# Altium schematic sheet IO Expander.SchDoc("IO Expander")
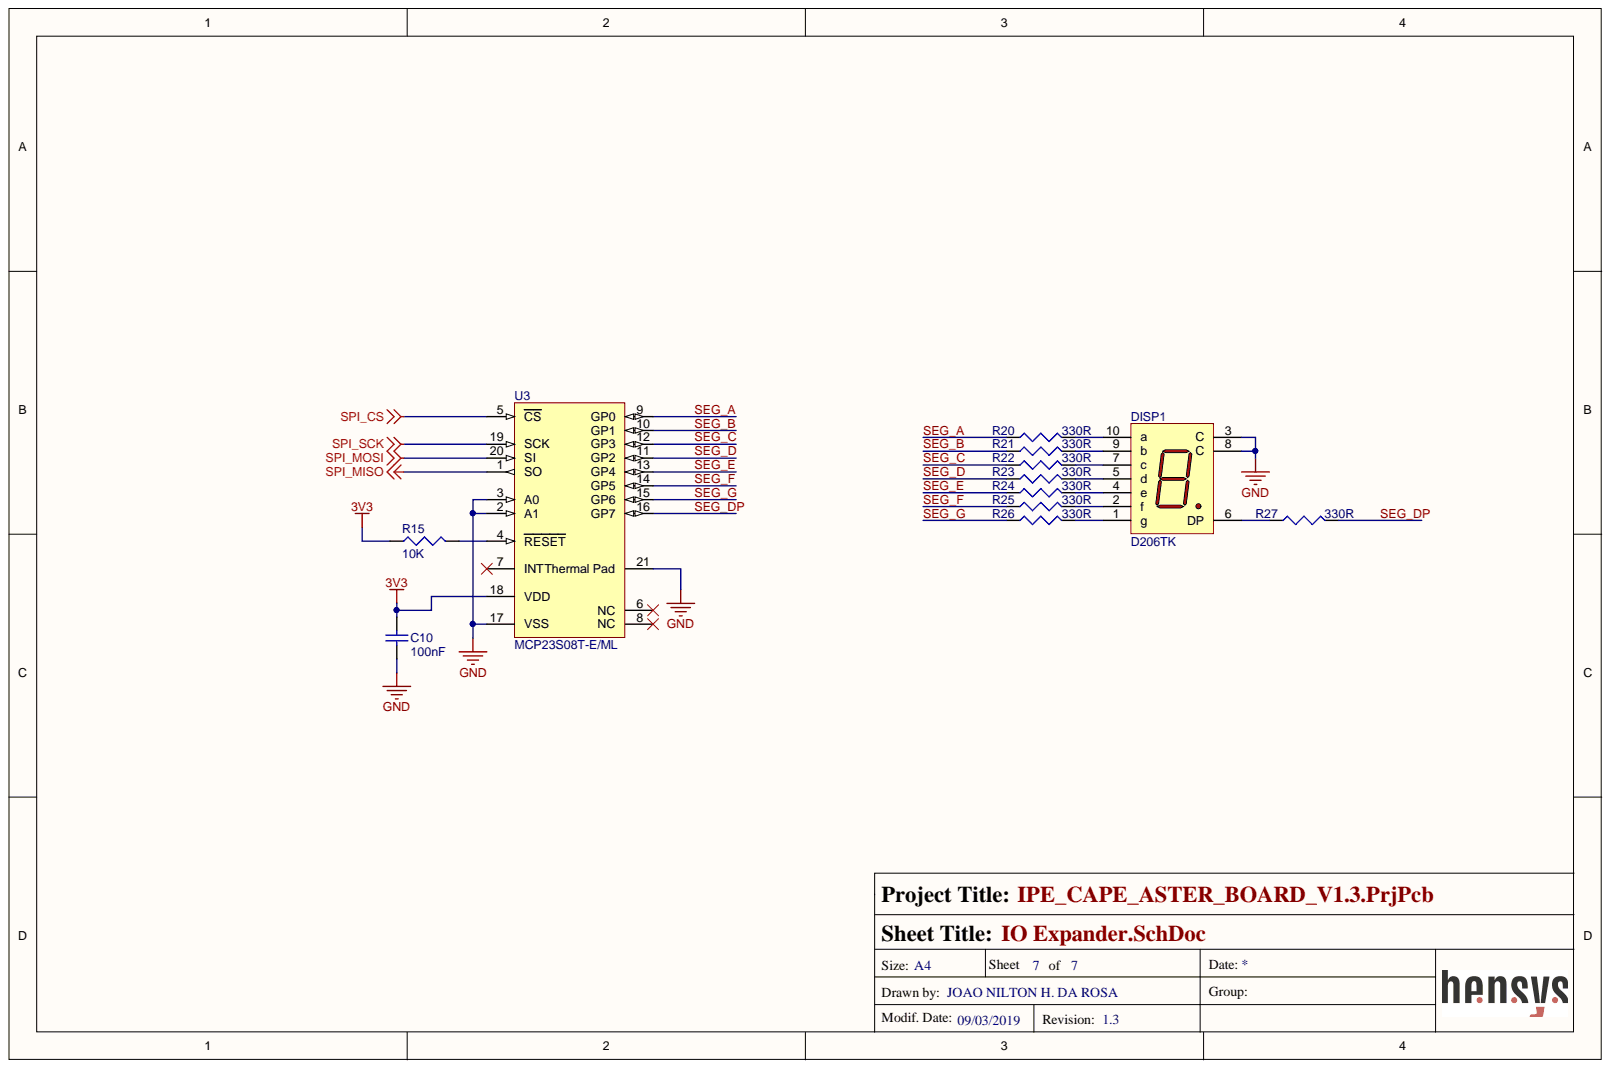
[diagram p0: IO Expander.SchDoc]

## Parts
C10  100nF  [0402 cap]
DISP1  D206TK  [D20XT_K_]
R15  10K  [0402 res]
R20  330R  [0603 res]
R21  330R  [0603 res]
R22  330R  [0603 res]
R23  330R  [0603 res]
R24  330R  [0603 res]
R25  330R  [0603 res]
R26  330R  [0603 res]
R27  330R  [0603 res]
U3  MCP23S08T-E/ML  [QFN-ML20_N]

## Nets
3V3: C10.2 R15.1 U3.18(VDD)
GND: C10.1 DISP1.3(C) DISP1.8(C) U3.2(A1) U3.3(A0) U3.17(VSS) U3.21
SPI_CS: U3.5(CS)
SPI_MISO: U3.1(SO)
SPI_MOSI: U3.20(SI)
SPI_SCK: U3.19(SCK)
SEG_A: R20.1 U3.9(GP0)
SEG_B: R21.1 U3.10(GP1)
SEG_C: R22.1 U3.12(GP3)
SEG_D: R23.1 U3.11(GP2)
SEG_DP: R27.2 U3.16(GP7)
SEG_E: R24.1 U3.13(GP4)
SEG_F: R25.1 U3.14(GP5)
SEG_G: R26.1 U3.15(GP6)
NetDISP1_1: DISP1.1(g) R26.2
NetDISP1_2: DISP1.2(f) R25.2
NetDISP1_4: DISP1.4(e) R24.2
NetDISP1_5: DISP1.5(d) R23.2
NetDISP1_6: DISP1.6(DP) R27.1
NetDISP1_7: DISP1.7(c) R22.2
NetDISP1_9: DISP1.9(b) R21.2
NetDISP1_10: DISP1.10(a) R20.2
NetR15_2: R15.2 U3.4(RESET)

Not connected: U3 6(NC) 7(INT_Thermal) 8(NC)

## Library data of the parts
C10 = Cap Ceram 0402: CAP CER 0.1UF 16V X7R 0402; Manufacturer Part Number: GCM155R71C104KA55D; Manufacturer: Murata Electronics North America; Tolerance: ±10%; Voltage - Rated: 16V; Thickness (Max): 0.022" (0.55mm); Temperature Coefficient: X7R; Supplier Part Number 1: 490-4759-1-ND; Supplier 1: Digi-Key; Stock 1: 918618; Size / Dimension: 0.039" L x 0.020" W (1.00mm x 0.50mm); RoHS: RoHS Compliant; Ratings: AEC-Q200; Pricing 1: 1=0.1, 10=0.039, 100=0.0174, 500=0.01244, 1000=0.00977, 2500=0.00888, 5000=0.00817 (USD); Part Status: Active; Packaging: Cut Tape (CT); Package / Case: 0402 (1005 Metric); Operating Temperature: -55°C ~ 125°C; Mounting Type: Surface Mount, MLCC; Lead Style: -; Lead Spacing: -; Height - Seated (Max): -; Features: -; ComponentLink1URL: http://psearch.en.murata.com/capacitor/product/GCM155R71C104KA55#.pdf; ComponentLink1Description: http://psearch.en.murata.com/capacitor/product/GCM155R71C104KA55#.pdf; Component Kind: Standard; Category: Capacitors; Applications: Automotive; Library Name: Passivos.IntLib
DISP1 = D206TK: 0.39" 7 segments display  common cathode; Manufacturer: Cromatek; Library Name: 7 Segments Display.IntLib; Component Kind: Standard
R15 = Resistor 0402: RES SMD 10K OHM 5% 1/16W 0402; Manufacturer Part Number: RC1005J103CS; Manufacturer: Samsung Electro-Mechanics; Temperature Coefficient: ±100ppm/°C; Power (Watts): 0.063W, 1/16W; Tolerance: ±5%; Supplier Part Number 1: 1276-4400-1-ND; Supplier Device Package: 0402; Supplier 1: Digi-Key; Stock 1: 523260; Size / Dimension: 0.039" L x 0.020" W (1.00mm x 0.50mm); RoHS: RoHS Compliant; Pricing 1: 1=0.1, 10=0.011, 100=0.0046, 1000=0.00207, 2500=0.0018, 5000=0.00148 (USD); Part Status: Active; Packaging: Cut Tape (CT); Package / Case: 0402 (1005 Metric); Operating Temperature: -55°C ~ 155°C; Height - Seated (Max): 0.016" (0.40mm); Features: Moisture Resistant; Failure Rate: -; Composition: Thick Film; ComponentLink1URL: http://www.samsungsem.com/kr/support/product-search/chip-resistor/RC1005J103CS.jsp; ComponentLink1Description: http://www.samsungsem.com/kr/support/product-search/chip-resistor/RC1005J103CS.jsp; Component Kind: Standard; Category: Resistors; Library Name: Passivos.IntLib
R20-R27 = Resistor 0603: RES SMD 330 OHM 1% 1/10W 0603; Manufacturer Part Number: RC1608F331CS; Manufacturer: Samsung Electro-Mechanics; Temperature Coefficient: ±100ppm/°C; Power (Watts): 0.1W, 1/10W; Tolerance: ±1%; Supplier Part Number 1: 1276-4604-6-ND; Supplier Device Package: 0603; Supplier 1: Digi-Key; Stock 1: 312119; Size / Dimension: 0.063" L x 0.032" W (1.60mm x 0.80mm); RoHS: RoHS Compliant; Pricing 1: 1=0.1, 10=0.025, 100=0.0101, 1000=0.00452, 2500=0.00392 (USD); Part Status: Active; Packaging: Digi-Reel®; Package / Case: 0603 (1608 Metric); Operating Temperature: -55°C ~ 155°C; Height - Seated (Max): 0.022" (0.55mm); Features: Moisture Resistant; Failure Rate: -; Composition: Thick Film; ComponentLink1URL: http://www.samsungsem.com/kr/support/product-search/chip-resistor/RC1608F331CS.jsp; ComponentLink1Description: http://www.samsungsem.com/kr/support/product-search/chip-resistor/RC1608F331CS.jsp; Component Kind: Standard; Category: Resistors; Library Name: Passivos.IntLib
U3 = MCP23S08T-E/ML: 8-Bit I/O Expander with Serial Interface, 20-Pin QFN, Extended Temperature, Tape and Reel; Manufacturer: Microchip; Supplier Part Number 2: 579-MCP23S08T-E/ML; Supplier Part Number 1: MCP23S08T-E/ML-ND; Supplier 2: Mouser; Supplier 1: Digi-Key; PartNumber: MCP23S08T-E/ML; PackageVersion: revBB, Aug-2009; PackageReference: QFN-ML20; PackageDescription: 20-Lead Plastic Quad Flat, No Lead Package (ML) - 4x4x0.9 mm Body [QFN]; Mounting Technology: Surface Mount; Library Name: Microchip Interface.IntLib; DatasheetVersion: revE, Aug-2007; ComponentLink3URL: http://www.microchip.com/stellent/groups/techpub_sg/documents/packagingspec/en012702.pdf; ComponentLink3Description: Package Specification; ComponentLink2URL: http://ww1.microchip.com/downloads/en/DeviceDoc/21919e.pdf; ComponentLink2Description: Datasheet; ComponentLink1URL: http://www.microchip.com/; ComponentLink1Description: Manufacturer URL; Component Kind: Standard
